# Altium schematic sheet KhoiPhu.SchDoc
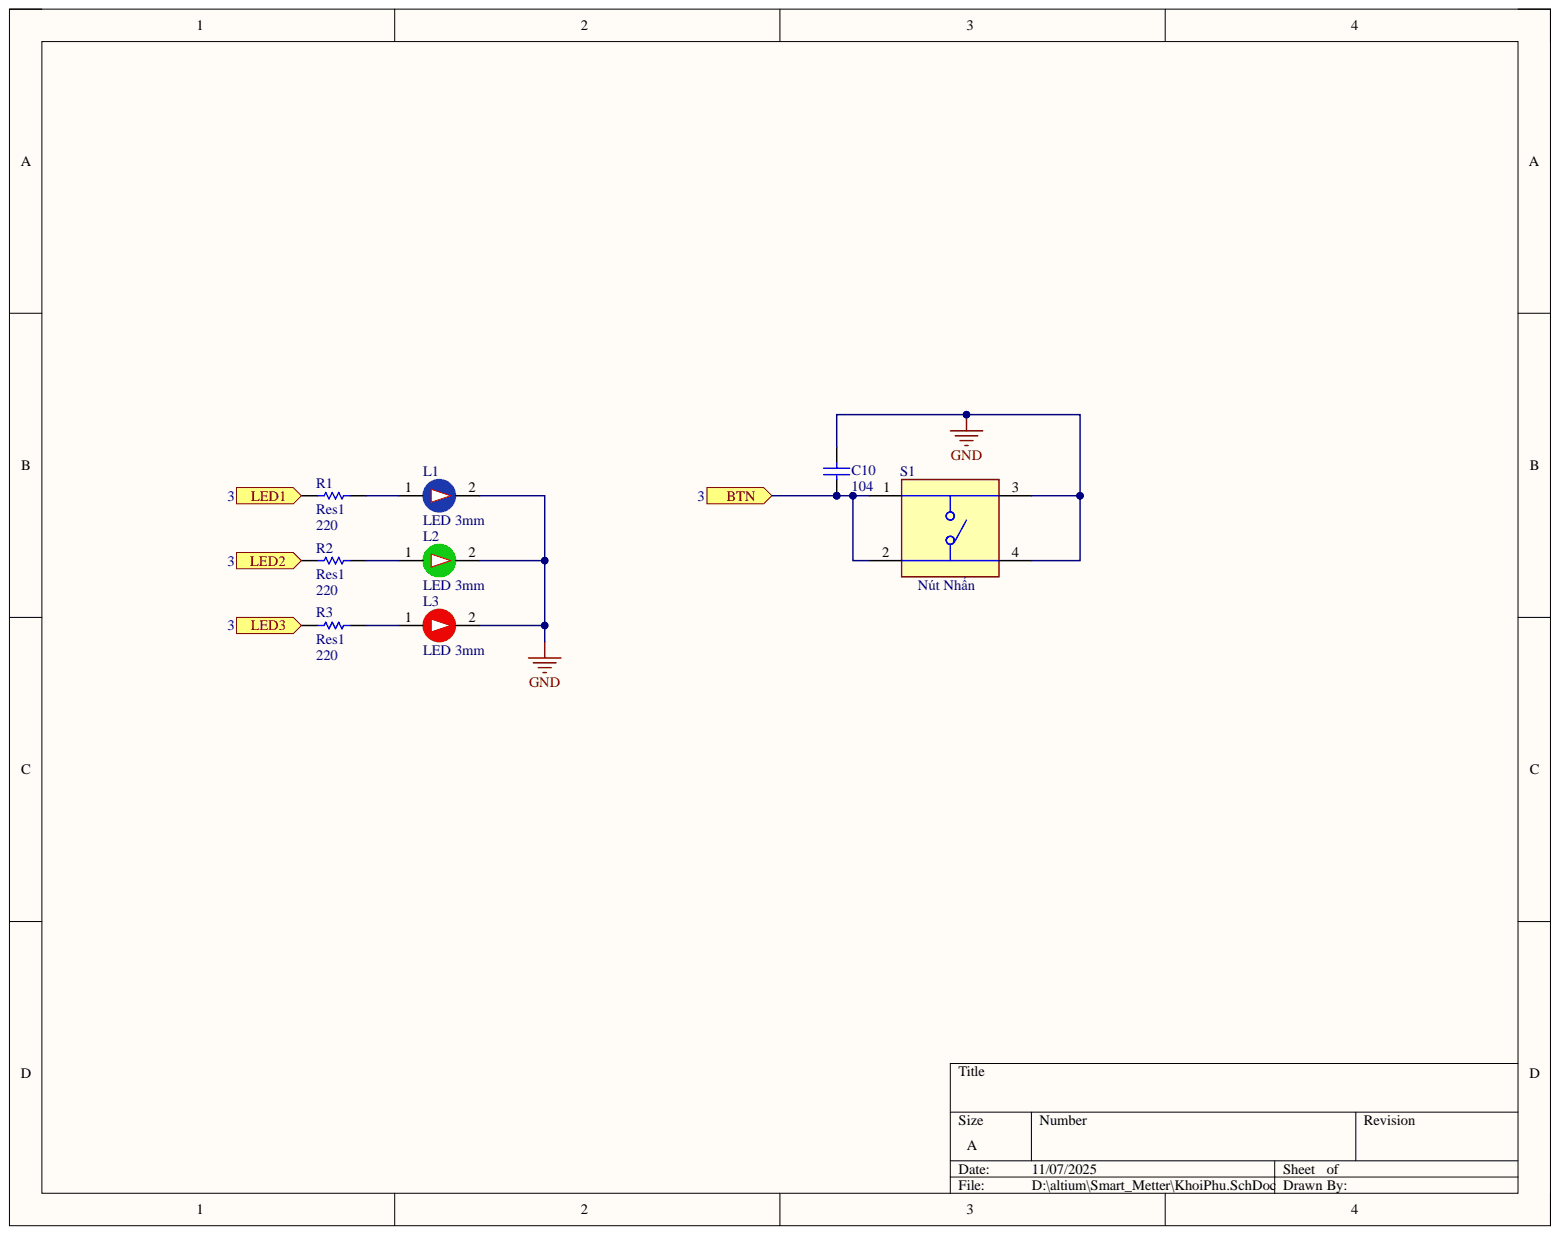
[diagram p0: KhoiPhu.SchDoc]

## Parts
C10  104  [tu gom]
L1  LED 3mm  [LED-3MM-BLUE]
L2  LED 3mm  [LED-3MM-GREEN]
L3  LED 3mm  [LED-3MM-RED]
R1  Res1  [AXIAL-0.3]
R2  Res1  value 220  [AXIAL-0.3]
R3  Res1  value 220  [AXIAL-0.3]
S1  Nút Nhấn  [SW_TS04-66-95-BK-100-SMT]

## Ports
BTN, LED1, LED2, LED3

## Nets
GND: C10.1 L1.2 L2.2 L3.2 S1.3 S1.4
BTN: C10.2 S1.1 S1.2 ; port BTN
LED1: R1.1 ; port LED1
LED2: R2.1 ; port LED2
LED3: R3.1 ; port LED3
NetL1_1: L1.1 R1.2
NetL2_1: L2.1 R2.2
NetL3_1: L3.1 R3.2

## Library data of the parts
C10 = Tu gom 104 50V: Cắm; Don_Vi: Con; Ma_Hang: MH004761; So_Chan: 2; Ten_Linh_Kien: Tụ gốm 104 100nF 50V; Chung_Loai: Cắm; Component Kind: Standard; Library Name: Altium_Lib_by_KhueNguyenCreator.IntLib
L1 = LED 3mm Xanh Duong: Cắm; Don_Vi: Con; Ma_Hang: MH009003; Ten_Linh_Kien: LED 3MM Xanh Dương SS (1000c); Chung_Loai: Cắm; Component Kind: Standard; Library Name: Altium_Lib_by_KhueNguyenCreator.IntLib
L2 = LED 3mm Xanh La: Cắm; Don_Vi: Con; Ma_Hang: MH001054; Ten_Linh_Kien: Led 3MM Phủ Xanh (10c); Chung_Loai: Cắm; Component Kind: Standard; Library Name: Altium_Lib_by_KhueNguyenCreator.IntLib
L3 = LED 3mm Do: Cắm; Don_Vi: Con; Ma_Hang: MH003892; Ten_Linh_Kien: Led 3MM Phủ Đỏ (10c); Chung_Loai: Cắm; Component Kind: Standard; Library Name: Altium_Lib_by_KhueNguyenCreator.IntLib
R1 = Res1: Resistor; Value: 220; Component Kind: Standard; LatestRevisionDate: 17-Jul-2002; LatestRevisionNote: Re-released for DXP Platform.; Library Name: Miscellaneous Devices.IntLib; PackageDescription: Axial Device, Thru-Hole; 2 Leads; 0.3 in Pin Spacing; PackageReference: AXIAL-0.3; Published: 8-Jun-2000; Signal Integrity: Res; Simulation: RESISTOR; Publisher: Altium Limited
R2 R3 = Res1: Resistor; Component Kind: Standard; LatestRevisionDate: 17-Jul-2002; LatestRevisionNote: Re-released for DXP Platform.; Library Name: Miscellaneous Devices.IntLib; PackageDescription: Axial Device, Thru-Hole; 2 Leads; 0.3 in Pin Spacing; PackageReference: AXIAL-0.3; Published: 8-Jun-2000; Signal Integrity: Res; Simulation: RESISTOR; Publisher: Altium Limited
S1 = TS04-66-95-BK-100-SMT: 6 x 6 mm, 9.5 mm Actuator Height, 100 gf, Black, Surface Mount, SPST, Tactile Switch; MF: Same Sky; Check_prices: https://www.snapeda.com/parts/TS04-66-95-BK-100-SMT/Same+Sky/view-part/?ref=eda; Component Kind: Standard; MP: TS04-66-95-BK-100-SMT; Package: None; SnapEDA_Link: https://www.snapeda.com/parts/TS04-66-95-BK-100-SMT/Same+Sky/view-part/?ref=snap; Library Name: nut nhan.IntLib
